# Altium schematic sheet Sheet1.SchDoc
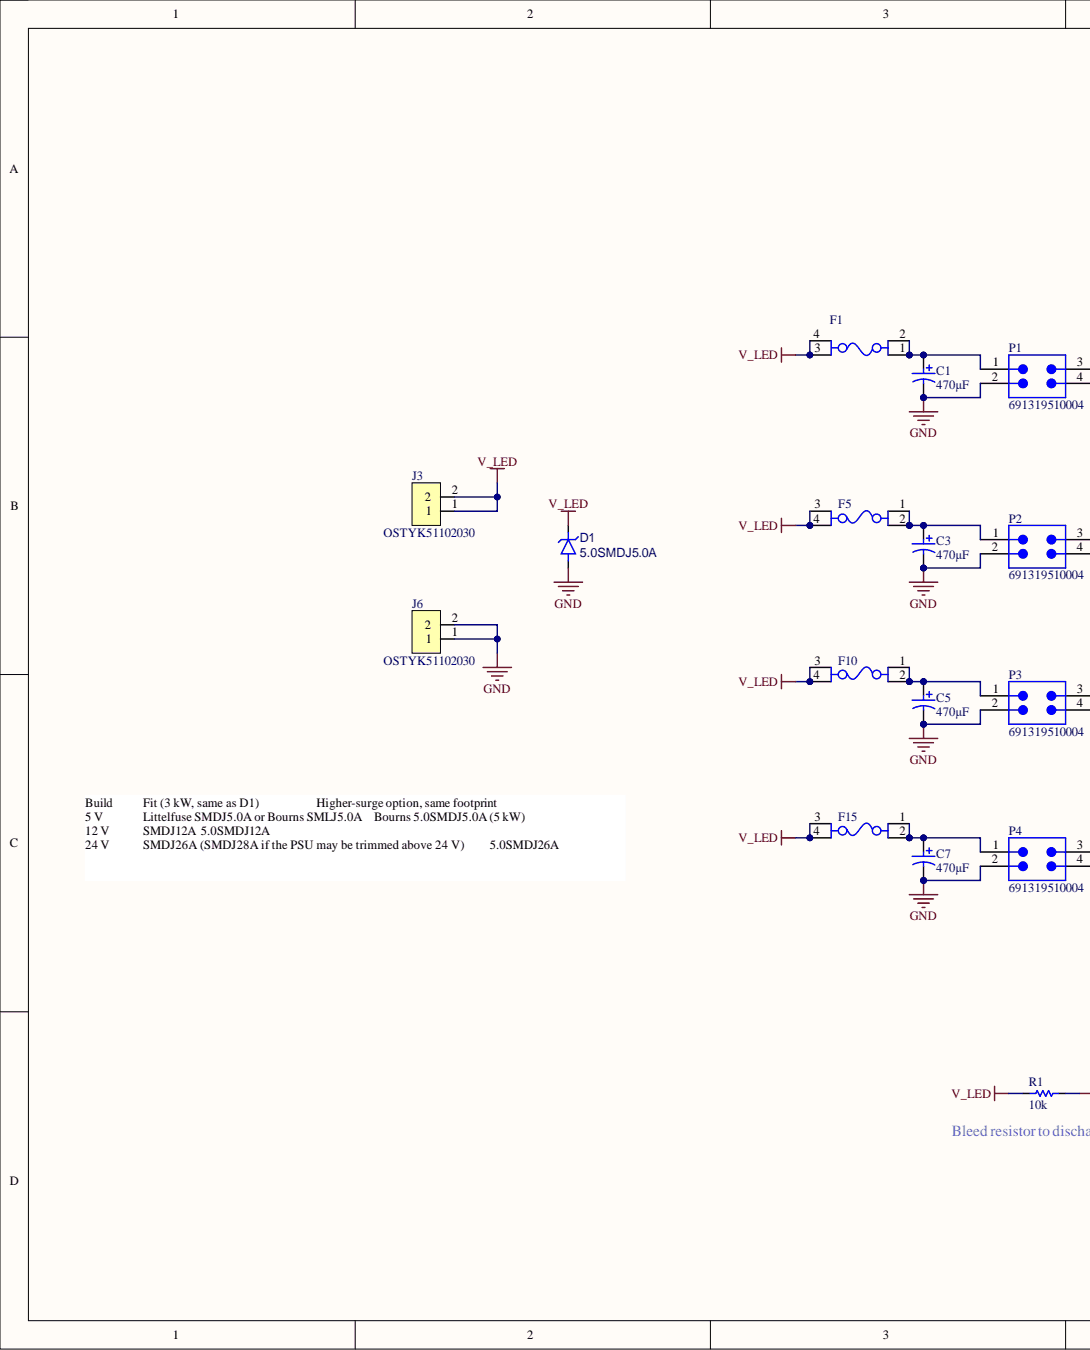
[diagram p0: Sheet1.SchDoc, left]
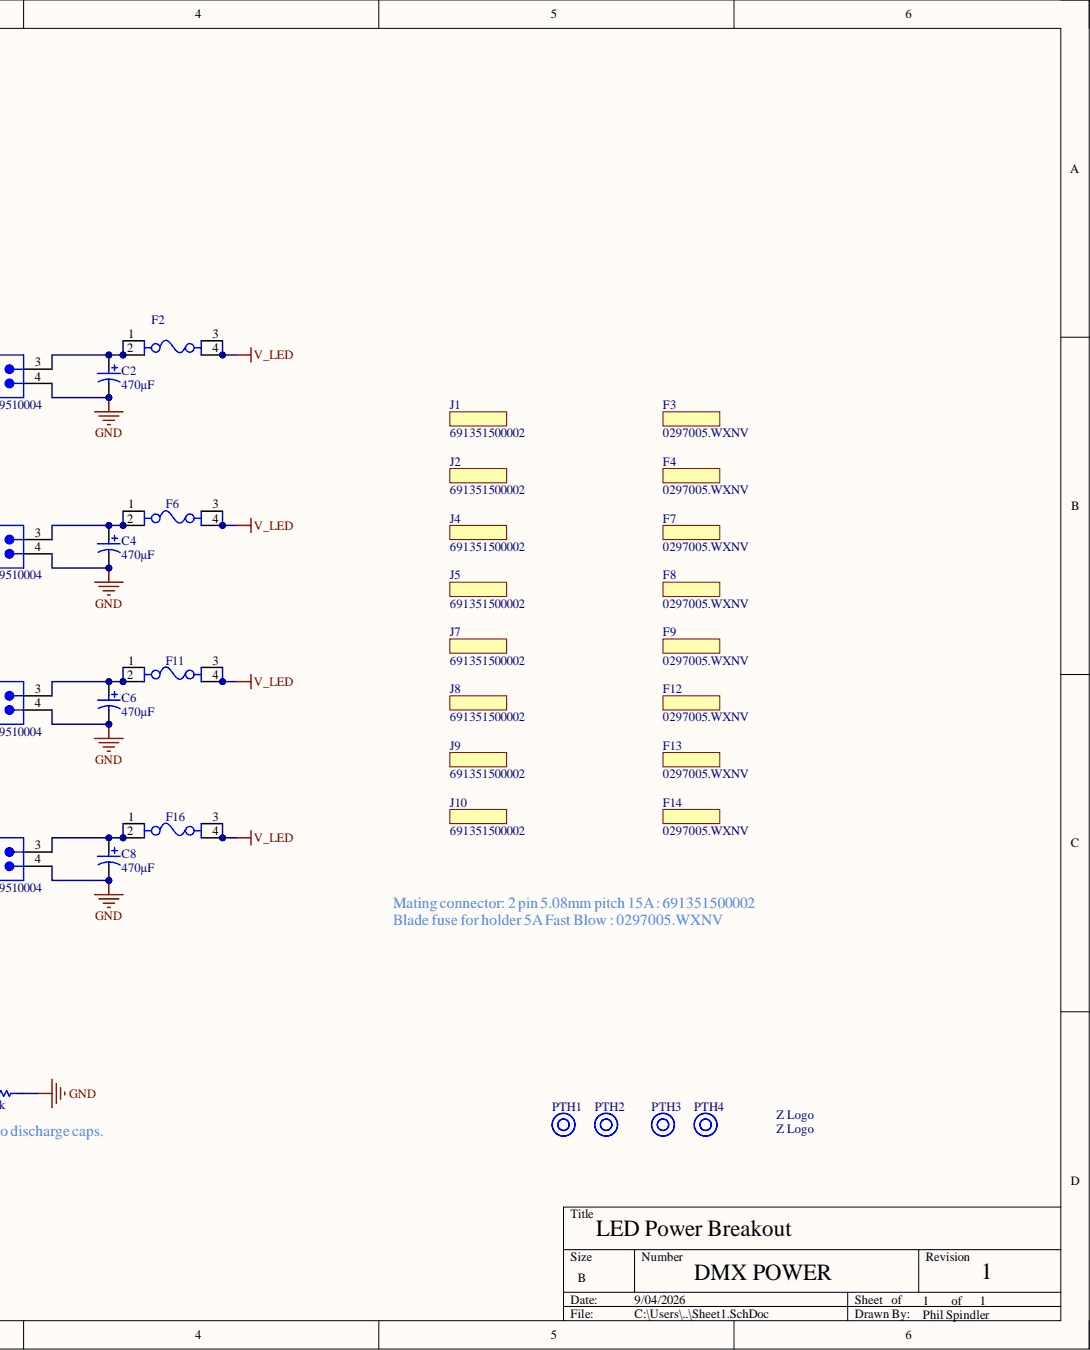
[diagram p1: Sheet1.SchDoc, right]

## Parts
_1  Z Logo  [Z Logo 0.5"]  (no BOM)
C1  value 470µF  [CAP_POL_DIAM10_H22_SPACING5]  (hidden comment: REH1020471M050K)
C2  value 470µF  [CAP_POL_DIAM10_H22_SPACING5]  (hidden comment: REH1020471M050K)
C3  value 470µF  [CAP_POL_DIAM10_H22_SPACING5]  (hidden comment: REH1020471M050K)
C4  value 470µF  [CAP_POL_DIAM10_H22_SPACING5]  (hidden comment: REH1020471M050K)
C5  value 470µF  [CAP_POL_DIAM10_H22_SPACING5]  (hidden comment: REH1020471M050K)
C6  value 470µF  [CAP_POL_DIAM10_H22_SPACING5]  (hidden comment: REH1020471M050K)
C7  value 470µF  [CAP_POL_DIAM10_H22_SPACING5]  (hidden comment: REH1020471M050K)
C8  value 470µF  [CAP_POL_DIAM10_H22_SPACING5]  (hidden comment: REH1020471M050K)
D1  5.0SMDJ5.0A  [SMDJ_DO-214AB]
F1  3544-2  [3544-2-Footprint-1]  (value not drawn)
F2  3544-2  [3544-2-Footprint-1]  (value not drawn)
F3  0297005.WXNV  [FP-0297-MFG]  (mechanical)
F4  0297005.WXNV  [FP-0297-MFG]  (mechanical)
F5  3544-2  [3544-2-Footprint-1]  (value not drawn)
F6  3544-2  [3544-2-Footprint-1]  (value not drawn)
F7  0297005.WXNV  [FP-0297-MFG]  (mechanical)
F8  0297005.WXNV  [FP-0297-MFG]  (mechanical)
F9  0297005.WXNV  [FP-0297-MFG]  (mechanical)
F10  3544-2  [3544-2-Footprint-1]  (value not drawn)
F11  3544-2  [3544-2-Footprint-1]  (value not drawn)
F12  0297005.WXNV  [FP-0297-MFG]  (mechanical)
F13  0297005.WXNV  [FP-0297-MFG]  (mechanical)
F14  0297005.WXNV  [FP-0297-MFG]  (mechanical)
F15  3544-2  [3544-2-Footprint-1]  (value not drawn)
F16  3544-2  [3544-2-Footprint-1]  (value not drawn)
J1  691351500002  [691351500002-Footprint-1]  (mechanical)
J2  691351500002  [691351500002-Footprint-1]  (mechanical)
J3  OSTYK51102030  [OSTYK51102030-Footprint-1]
J4  691351500002  [691351500002-Footprint-1]  (mechanical)
J5  691351500002  [691351500002-Footprint-1]  (mechanical)
J6  OSTYK51102030  [OSTYK51102030-Footprint-1]
J7  691351500002  [691351500002-Footprint-1]  (mechanical)
J8  691351500002  [691351500002-Footprint-1]  (mechanical)
J9  691351500002  [691351500002-Footprint-1]  (mechanical)
J10  691351500002  [691351500002-Footprint-1]  (mechanical)
P1  691319510004  [691319510004]
P2  691319510004  [691319510004]
P3  691319510004  [691319510004]
P4  691319510004  [691319510004]
PTH1  Mounting Hole NPTH  [Mounting Hole M3 No Washer NPTH]  (value not drawn)  (no BOM)
PTH2  Mounting Hole NPTH  [Mounting Hole M3 No Washer NPTH]  (value not drawn)  (no BOM)
PTH3  Mounting Hole NPTH  [Mounting Hole M3 No Washer NPTH]  (value not drawn)  (no BOM)
PTH4  Mounting Hole NPTH  [Mounting Hole M3 No Washer NPTH]  (value not drawn)  (no BOM)
R1  value 10k  [RESC3116X06N_1206MED]  (hidden comment: RC1206FR-0710KL)

## Nets
GND: C1.2 C2.2 C3.2 C4.2 C5.2 C6.2 C7.2 C8.2 D1.2 J6.1 J6.2 P1.2 P1.4 P2.2 P2.4 P3.2 P3.4 P4.2 P4.4 R1.2
V_LED: D1.1 F1.3 F1.4 F2.3 F2.4 F5.3 F5.4 F6.3 F6.4 F10.3 F10.4 F11.3 F11.4 F15.3 F15.4 F16.3 F16.4 J3.1 J3.2 R1.1
NetC1_1: C1.1 F1.1 F1.2 P1.1
NetC2_1: C2.1 F2.1 F2.2 P1.3
NetC3_1: C3.1 F5.1 F5.2 P2.1
NetC4_1: C4.1 F6.1 F6.2 P2.3
NetC5_1: C5.1 F10.1 F10.2 P3.1
NetC6_1: C6.1 F11.1 F11.2 P3.3
NetC7_1: C7.1 F15.1 F15.2 P4.1
NetC8_1: C8.1 F16.1 F16.2 P4.3

## Library data of the parts
_1 = CMP-00028-00046-1: Z logo silkscreen; Library Name: Equus Computer Systems
C1-C8 = CMP-001-00166-2: CAP ALUM 470UF 20% 50V RADIAL TH; Capacitor Type: Aluminium; Case/Package: Radial; Component Kind: Standard; Max Operating Temperature: -40°C; Min Operating Temperature: 125°C; Mounting Technology: Through Hole; Pins: 2; RoHS Compliant: Yes; Tolerance: 20%; Voltage Rating: 50V; Library Name: Equus Computer Systems
D1 = CMP-004-00056-1: 5V Unidirectional 5kW TVS Diode, 9.2V Vwm, 6.4V Vbr, SMC(DO-214AB), SMD; Max Surge Current: 326.1A; Component Kind: Standard; Forward Voltage: 5V; Max Operating Temperature: 150°C; Min Operating Temperature: -55°C; Mounting Technology: Surface Mount; Pins: 2; Library Name: Equus Computer Systems
F1 F2 F5 F6 F10 F11 F15 F16 = CMP-005-00025-1: Auto blade fuse holder; for 2.8x0.8mm mini blade fuses ATM; 20A; THT; Current Rating: 30A; Component Kind: Standard; Max Operating Temperature: 145°C; Min Operating Temperature: -50°C; Mounting Technology: PCB, Through Hole, Vertical; Library Name: Equus Computer Systems
F3 F4 F7-F9 F12-F14 = CMP-005-00024-3: Fuse Blade 5A 32V Socket 10.9 X 3.8 X 8.8mm Polyamide 66 Automotive UL; Current Rating: 5A; Max Operating Temperature: 125°C; Min Operating Temperature: -40°C; Mounting Technology: Holder, Socket; Pins: 2; Library Name: Equus Computer Systems
J1 J2 J4 J5 J7-J10 = CMP-002-00248-4: Terminal Block, Pluggable, 2Pos, 12Awg;; Gender: Female; Voltage Rating: 300V; Max Operating Temperature: 105°C; Min Operating Temperature: -40°C; Mounting Technology: Cable; Pins: 2; Library Name: Equus Computer Systems
J3 J6 = CMP-002-00246-1: Conn Terminal Block 2 POS 9.5mm Solder ST Thru-Hole 30A; Max Current Rating: 20A; Voltage Rating: 300V; Component Kind: Standard; Max Operating Temperature: 115°C; Min Operating Temperature: -40°C; Mounting Technology: Screw; Pins: 2; Library Name: Equus Computer Systems
P1-P4 = CMP-002-00244-2: Terminal Block, 5.08MM, Dbl Lvl Horizontal Male Pcb Header W/closed Flange, 4PI; Max Current Rating: 10A; Component Kind: Standard; Max Operating Temperature: 105°C; Min Operating Temperature: -40°C; Pins: 4; Library Name: Equus Computer Systems
PTH1-PTH4 = CMP-00028-00043-4: Description: Mounting Hole NPTH; Library Name: Equus Computer Systems
R1 = CMP-009-00344-1: Fixed Resistor, Metal Glaze/thick Film, 0.25W, 20000ohm, 200V, 1% +/-Tol, 100ppm/Cel, Surface Mount, 1206; Power: 250mW; Case/Package: 1206; Component Kind: Standard; Max Operating Temperature: 155°C; Min Operating Temperature: -55°C; Mounting Technology: Surface Mount; Pins: 2; RoHS Compliant: Yes; Tolerance: 1%; Voltage Rating: 200V; Library Name: Equus Computer Systems
